# Altium schematic sheet Connectors.SchDoc
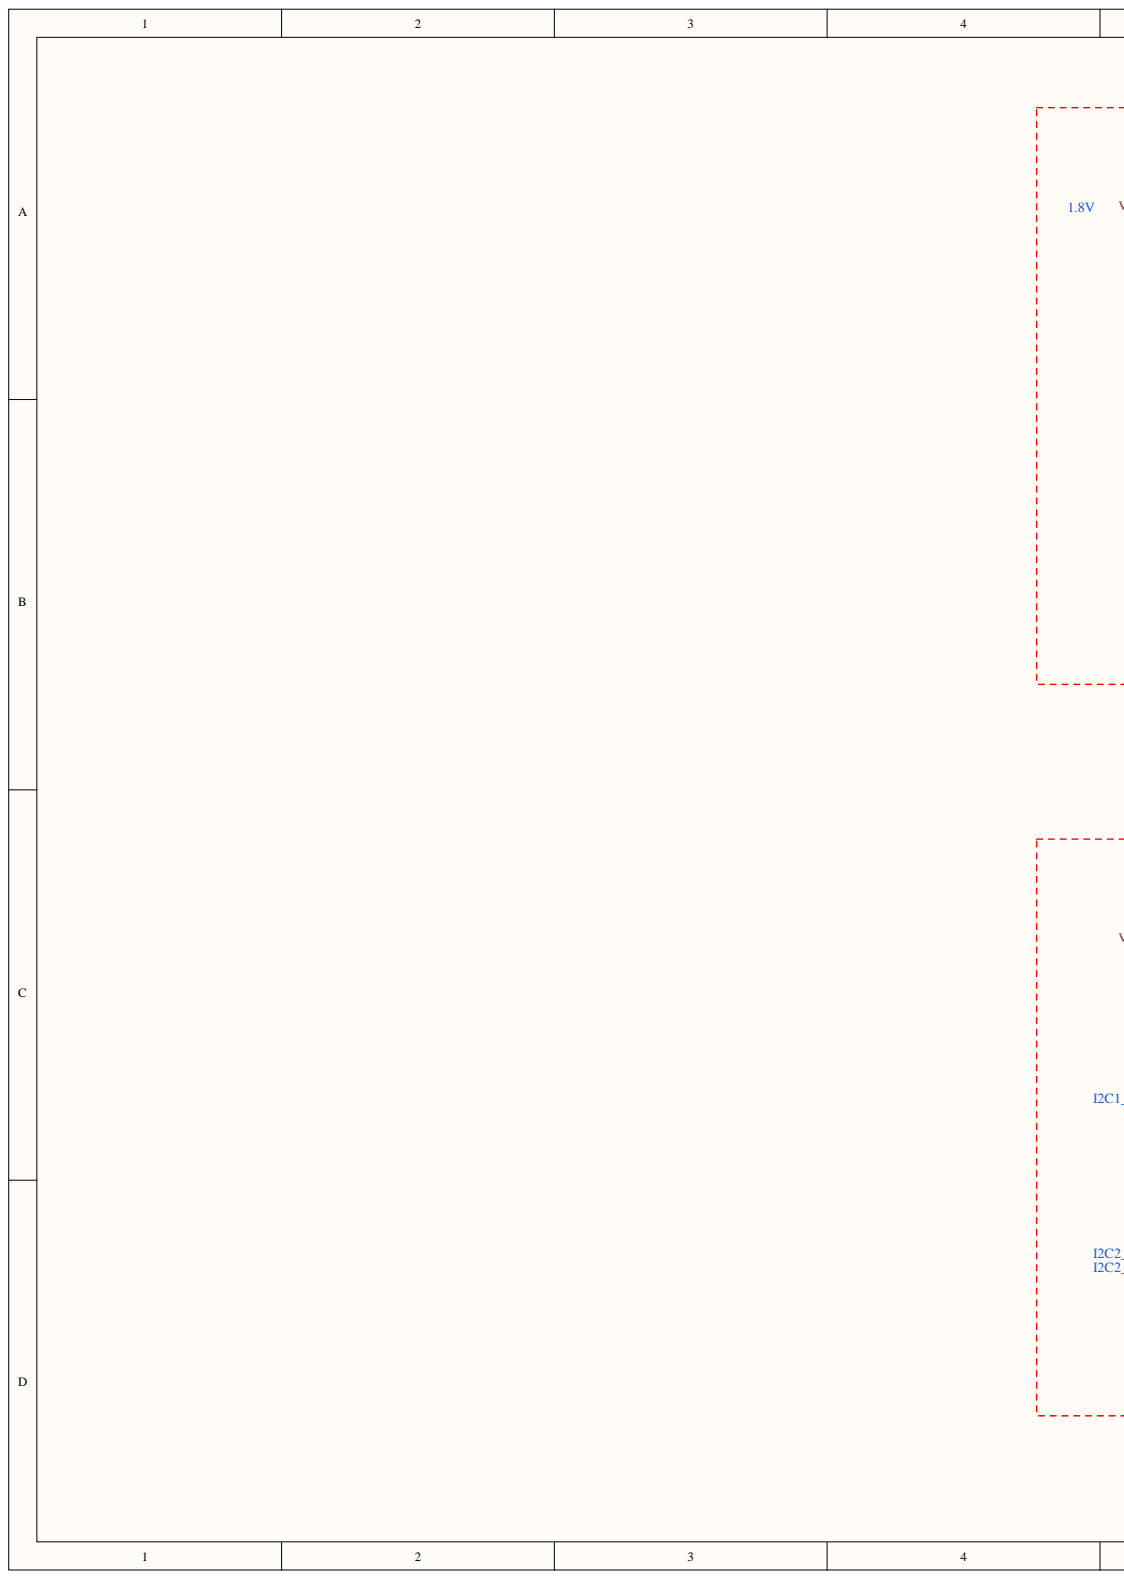
[diagram p0: Connectors.SchDoc, left]
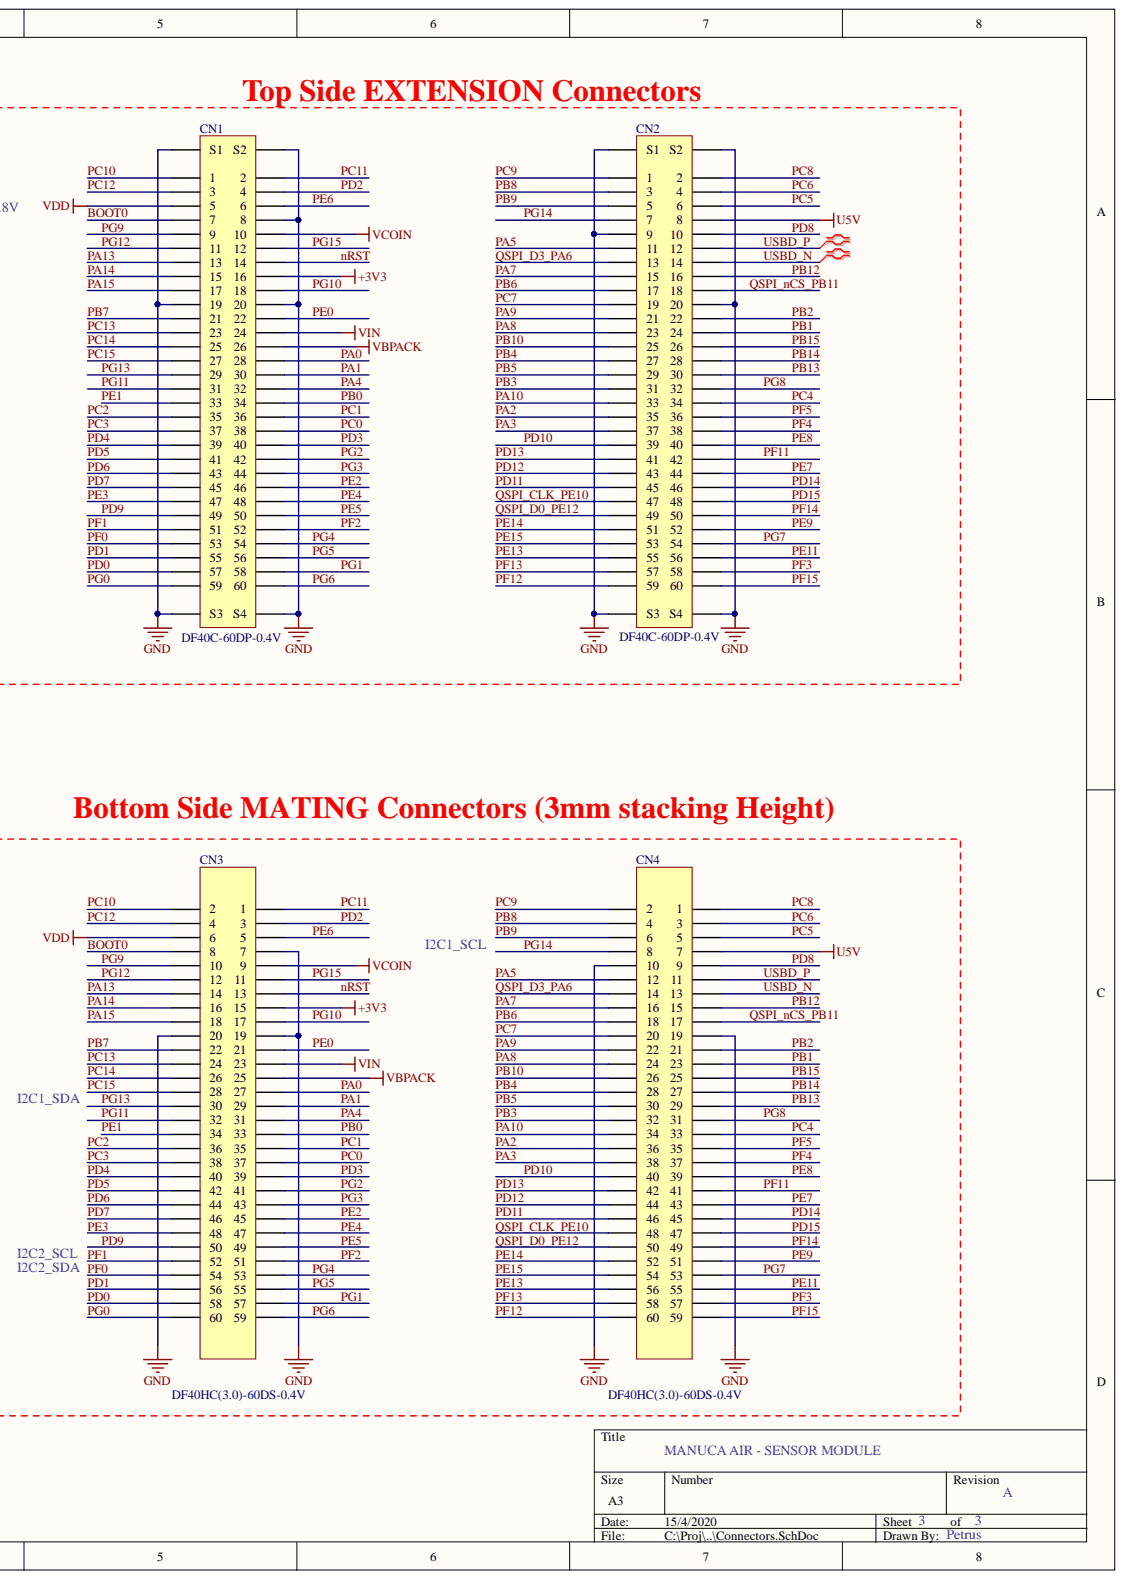
[diagram p1: Connectors.SchDoc, right]

## Parts
CN1  DF40C-60DP-0.4V  [DF40C-60DP-0.4V(51)]
CN2  DF40C-60DP-0.4V  [DF40C-60DP-0.4V(51)]
CN3  DF40HC(3.0)-60DS-0.4V  [DF40HC-(3.0)-60DS-04V]
CN4  DF40HC(3.0)-60DS-0.4V  [DF40HC-(3.0)-60DS-04V]

## Nets
+3V3: CN1.16 CN3.15
GND: CN1.8 CN1.19 CN1.20 CN1.S1 CN1.S2 CN1.S3 CN1.S4 CN2.9 CN2.20 CN2.S1 CN2.S2 CN2.S3 CN2.S4 CN3.7 CN3.19 CN3.20 CN4.10 CN4.19
U5V: CN2.8 CN4.7
VBPACK: CN1.26 CN3.25
VCOIN: CN1.10 CN3.9
VDD: CN1.5 CN3.6
VIN: CN1.24 CN3.23
BOOT0: CN1.7 CN3.8
nRST: CN1.14 CN3.13
PA0: CN1.28 CN3.27
PA1: CN1.30 CN3.29
PA2: CN2.35 CN4.36
PA3: CN2.37 CN4.38
PA4: CN1.32 CN3.31
PA5: CN2.11 CN4.12
PA7: CN2.15 CN4.16
PA8: CN2.23 CN4.24
PA9: CN2.21 CN4.22
PA10: CN2.33 CN4.34
PA13: CN1.13 CN3.14
PA14: CN1.15 CN3.16
PA15: CN1.17 CN3.18
PB0: CN1.34 CN3.33
PB1: CN2.24 CN4.23
PB2: CN2.22 CN4.21
PB3: CN2.31 CN4.32
PB4: CN2.27 CN4.28
PB5: CN2.29 CN4.30
PB6: CN2.17 CN4.18
PB7: CN1.21 CN3.22
PB8: CN2.3 CN4.4
PB9: CN2.5 CN4.6
PB10: CN2.25 CN4.26
PB12: CN2.16 CN4.15
PB13: CN2.30 CN4.29
PB14: CN2.28 CN4.27
PB15: CN2.26 CN4.25
PC0: CN1.38 CN3.37
PC1: CN1.36 CN3.35
PC2: CN1.35 CN3.36
PC3: CN1.37 CN3.38
PC4: CN2.34 CN4.33
PC5: CN2.6 CN4.5
PC6: CN2.4 CN4.3
PC7: CN2.19 CN4.20
PC8: CN2.2 CN4.1
PC9: CN2.1 CN4.2
PC10: CN1.1 CN3.2
PC11: CN1.2 CN3.1
PC12: CN1.3 CN3.4
PC13: CN1.23 CN3.24
PC14: CN1.25 CN3.26
PC15: CN1.27 CN3.28
PD0: CN1.57 CN3.58
PD1: CN1.55 CN3.56
PD2: CN1.4 CN3.3
PD3: CN1.40 CN3.39
PD4: CN1.39 CN3.40
PD5: CN1.41 CN3.42
PD6: CN1.43 CN3.44
PD7: CN1.45 CN3.46
PD8: CN2.10 CN4.9
PD9: CN1.49 CN3.50
PD10: CN2.39 CN4.40
PD11: CN2.45 CN4.46
PD12: CN2.43 CN4.44
PD13: CN2.41 CN4.42
PD14: CN2.46 CN4.45
PD15: CN2.48 CN4.47
PE0: CN1.22 CN3.21
PE1: CN1.33 CN3.34
PE2: CN1.46 CN3.45
PE3: CN1.47 CN3.48
PE4: CN1.48 CN3.47
PE5: CN1.50 CN3.49
PE6: CN1.6 CN3.5
PE7: CN2.44 CN4.43
PE8: CN2.40 CN4.39
PE9: CN2.52 CN4.51
PE11: CN2.56 CN4.55
PE13: CN2.55 CN4.56
PE14: CN2.51 CN4.52
PE15: CN2.53 CN4.54
PF0: CN1.53 CN3.54
PF1: CN1.51 CN3.52
PF2: CN1.52 CN3.51
PF3: CN2.58 CN4.57
PF4: CN2.38 CN4.37
PF5: CN2.36 CN4.35
PF11: CN2.42 CN4.41
PF12: CN2.59 CN4.60
PF13: CN2.57 CN4.58
PF14: CN2.50 CN4.49
PF15: CN2.60 CN4.59
PG0: CN1.59 CN3.60
PG1: CN1.58 CN3.57
PG2: CN1.42 CN3.41
PG3: CN1.44 CN3.43
PG4: CN1.54 CN3.53
PG5: CN1.56 CN3.55
PG6: CN1.60 CN3.59
PG7: CN2.54 CN4.53
PG8: CN2.32 CN4.31
PG9: CN1.9 CN3.10
PG10: CN1.18 CN3.17
PG11: CN1.31 CN3.32
PG12: CN1.11 CN3.12
PG13: CN1.29 CN3.30
PG14: CN2.7 CN4.8
PG15: CN1.12 CN3.11
QSPI_CLK_PE10: CN2.47 CN4.48
QSPI_D0_PE12: CN2.49 CN4.50
QSPI_D3_PA6: CN2.13 CN4.14
QSPI_nCS_PB11: CN2.18 CN4.17
USBD_N: CN2.14 CN4.13
USBD_P: CN2.12 CN4.11

## Library data of the parts
CN1 CN2 = DF40C-60DP: Connector, DF40 Series Header 60 pin no enforcing metal fitting; Manufacturer: Hirose; Component Kind: Standard; PartNumber: DF40C-60DP-0.4V(51); Library Name: SensorPOD_RevC.SCHLIB
CN3 CN4 = DF40HC(3.0)-60DS: Connector, Hirose DF40 series receptacle 60 pin 3mm stacking height no enforcing metal fitting; PartNumber: DF40HC(3.0)-60DS-0.4V(51); Component Kind: Standard; Manufacturer: Hirose; Library Name: SensorPOD_RevC.SCHLIB
